# Altium schematic sheet 12-Charging LED Indicator.SchDoc("12-Charging LED Indicator")
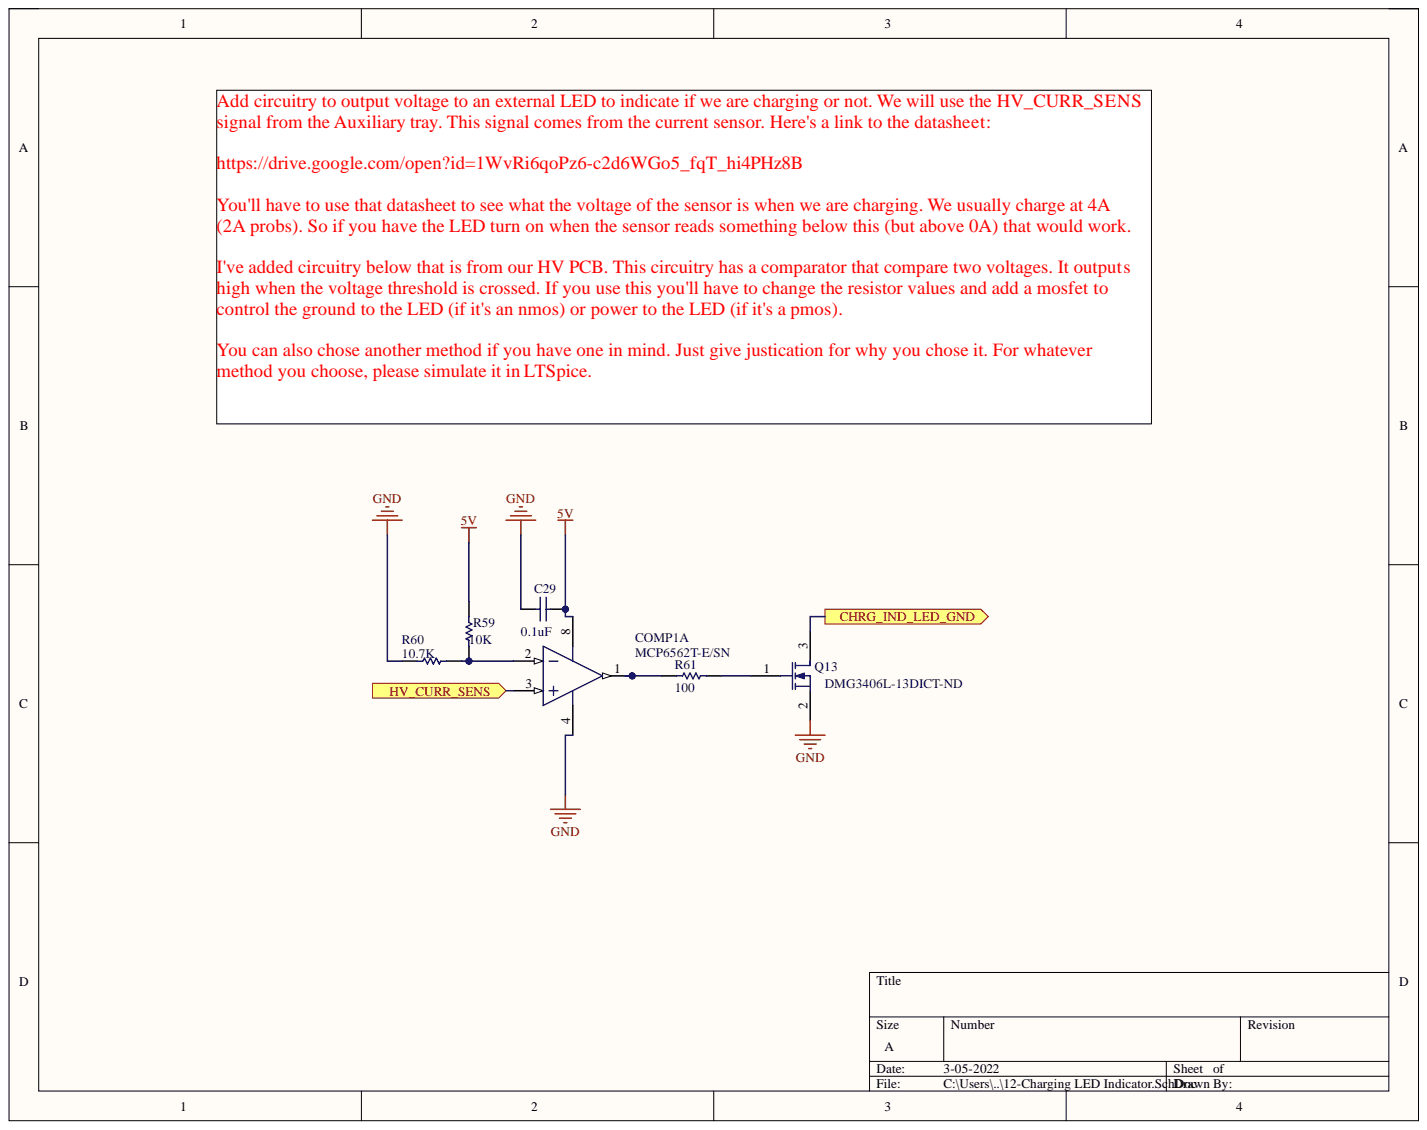
[diagram p0: 12-Charging LED Indicator.SchDoc]

## Parts
C29  0.1uF  [1206 (3216 Metric)]
COMP1  MCP6562T-E/SN  [SOIC-SN8_M]  (part A)
Q13  Supplier Part Number 1: DMG3406L-13DICT-ND  [SOT-23_N]
R59  10K  [1206 (3216 Metric)]
R60  10.7K  [1206 (3216 Metric)]
R61  100  [1206 (3216 Metric)]

## Ports
CHRG_IND_LED_GND, HV_CURR_SENS

## Nets
5V: C29.2 COMP1.8 R59.1
GND: C29.1 COMP1.4 Q13.2 R60.1
CHRG_IND_LED_GND: Q13.3 ; port CHRG_IND_LED_GND
HV_CURR_SENS: COMP1.3 ; port HV_CURR_SENS
NetCOMP1_1: COMP1.1 R61.1
NetCOMP1_2: COMP1.2 R59.2 R60.2
NetQ13_1: Q13.1 R61.2

## Library data of the parts
C29 = Cap: CGA5L4X7T2W104M160AA: CAP CER 0.1UF 450V X7T 1206; Component Kind: Standard; LatestRevisionDate: 17-Jul-2002; LatestRevisionNote: Re-released for DXP Platform.; Library Name: Miscellaneous Devices.IntLib; PackageDescription: Radial Cap, Thru-Hole; 2 Leads; 0.3 in Pin Spacing; PackageReference: RAD-0.3; Published: 8-Jun-2000; Signal Integrity: Cap; Simulation: CAP; Supplier 1: Digi-Key; Supplier Part Number 1: 445-12959-1-ND; Value: 0.1uF; Publisher: Altium Limited
COMP1 = CMP-0187-00236-3: None; Common Mode Rejection Ratio: 66dB; Hysteresis: 5mV; Input Bias Current: 1pA; Input Offset Voltage (Vos): 10mV; Max Supply Voltage: 5.5V; Min Supply Voltage: 1.8V; Number of Channels: 2; Output Current: 50mA; Output Type: TTL; Power Supply Rejection Ratio (PSRR): 70dB; Propagation Delay: 80ns; Quiescent Current: 130uA; Case/Package: SOIC; Component Kind: Standard; Lead Free: Lead Free; Library Name: Altium Content Vault; Max Operating Temperature: 125°C; Min Operating Temperature: -40°C; Mount: Surface Mount; Number of Elements: 2; Number of Pins: 8; Package Quantity: 3300; Packaging: Tape and Reel; Radiation Hardening: No; RoHS Compliant: Yes; Simulation: MCP6561; Technology: CMOS; Type: General Purpose
Q13 = MOSFET-N: N-Channel MOSFET; Manufacturer: Infineon Technologies; Manufacturer Part Number: IPD075N03L G; Current - Continuous Drain (Id) @ 25°C: 50A (Tc); Drain to Source Voltage (Vdss): 30V; FET Feature: Logic Level Gate; FET Type: MOSFET N-Channel, Metal Oxide; Gate Charge (Qg) @ Vgs: 18nC @ 10V; Input Capacitance (Ciss) @ Vds: 1900pF @ 15V; Power - Max: 47W; Rds On (Max) @ Id, Vgs: 7.5 mOhm @ 30A, 10V; Vgs(th) (Max) @ Id: 2.2V @ 250µA; Category: Discrete Semiconductor Products; Component Kind: Standard; ComponentLink1Description: http://www.infineon.com/dgdl/Infineon-IPD075N03LG-DS-v02_01-en.pdf?folderId=5546d4694909da4801490a07012f053b&fileId=db3a30431936bc4b011951d824f83aeb; ComponentLink1URL: http://www.infineon.com/dgdl/Infineon-IPD075N03LG-DS-v02_01-en.pdf?folderId=5546d4694909da4801490a07012f053b&fileId=db3a30431936bc4b011951d824f83aeb; ComponentLink2Description: http://www.semicon.toshiba.co.jp/info/docget.jsp?type=datasheet&lang=en&pid=SSM3K329R; ComponentLink2URL: http://www.semicon.toshiba.co.jp/info/docget.jsp?type=datasheet&lang=en&pid=SSM3K329R; LatestRevisionDate: 17-Jul-2002; LatestRevisionNote: Re-released for DXP Platform.; Library Name: Miscellaneous Devices.IntLib; Mounting Type: Surface Mount; Package / Case: TO-252-3, DPak (2 Leads + Tab), SC-63; PackageDescription: TO, Flat Index; 3 In-Line, Axial Leads; Body Dia. 4.6mm; Lead Dia. 0.5 mm (max); PackageReference: E3; PackageVersion: 1995/1996; Packaging: Cut Tape (CT); Published: 8-Jun-2000; RoHS: RoHS Compliant; Simulation: NMOS; Supplier 1: Digi-Key; Supplier Device Package: PG-TO252-3; Publisher: Altium Limited
R59 = Res1: Component Kind: Standard; LatestRevisionDate: 17-Jul-2002; LatestRevisionNote: Re-released for DXP Platform.; Library Name: Miscellaneous Devices.IntLib; PackageDescription: Axial Device, Thru-Hole; 2 Leads; 0.3 in Pin Spacing; PackageReference: AXIAL-0.3; Published: 8-Jun-2000; Signal Integrity: Res; Simulation: RESISTOR; Supplier 1: Digi-Key; Supplier Part Number 1: RMCF1206FT10K0CT-ND; Value: 10K; Publisher: Altium Limited
R60 = Res1: KTR18EZPF1501: RES SMD 1.5K OHM 1% 1/4W 1206; Component Kind: Standard; LatestRevisionDate: 17-Jul-2002; LatestRevisionNote: Re-released for DXP Platform.; Library Name: Miscellaneous Devices.IntLib; PackageDescription: Axial Device, Thru-Hole; 2 Leads; 0.3 in Pin Spacing; PackageReference: AXIAL-0.3; Published: 8-Jun-2000; Signal Integrity: Res; Simulation: RESISTOR; Supplier 1: Digi-Key; Supplier Part Number 1: RNCP1206FTD10K7CT-ND; Value: 10.7K; Publisher: Altium Limited
R61 = Res1: KTR18EZPJ101: RES SMD 100 OHM 5% 1/4W 1206; Component Kind: Standard; LatestRevisionDate: 17-Jul-2002; LatestRevisionNote: Re-released for DXP Platform.; Library Name: Miscellaneous Devices.IntLib; PackageDescription: Axial Device, Thru-Hole; 2 Leads; 0.3 in Pin Spacing; PackageReference: AXIAL-0.3; Published: 8-Jun-2000; Signal Integrity: Res; Simulation: RESISTOR; Supplier 1: Digi-Key; Supplier Part Number 1: RHM100PCT-ND; Value: 100; Publisher: Altium Limited
